# Altium schematic sheet ESSICC-ALM-SPI-232.SchDoc(ESSICC-ALM-SPI-232)
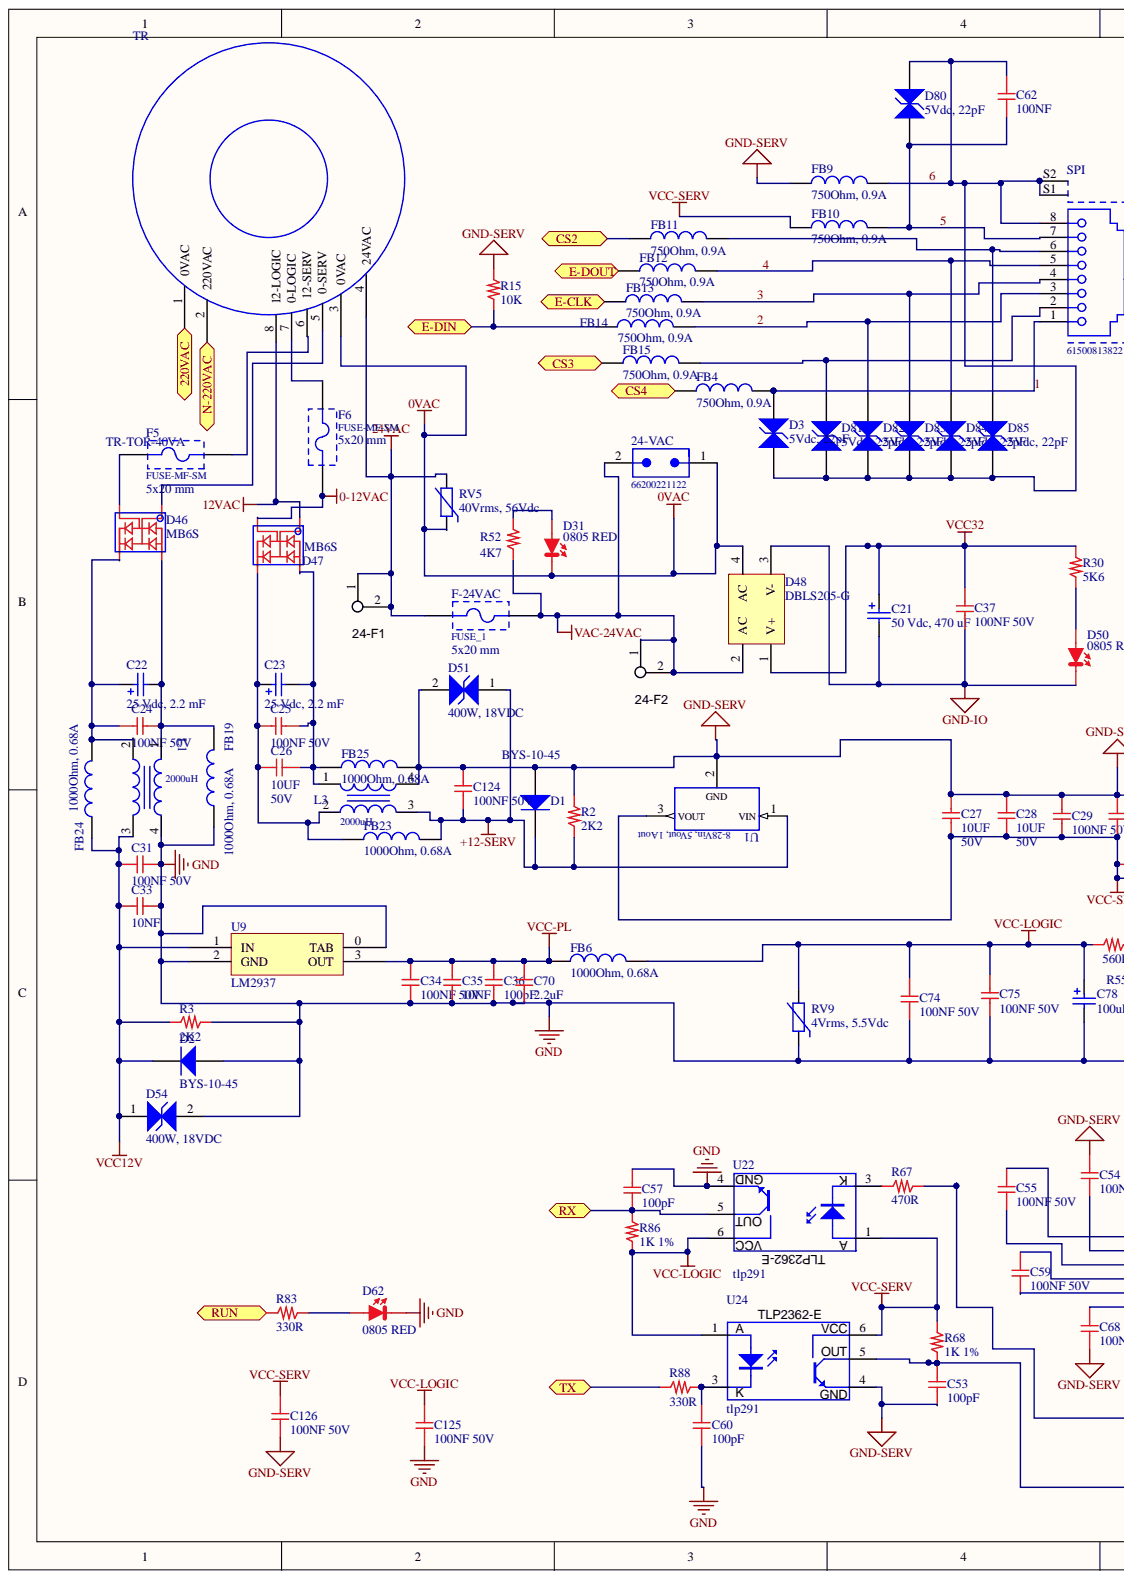
[diagram p0: ESSICC-ALM-SPI-232.SchDoc, left]
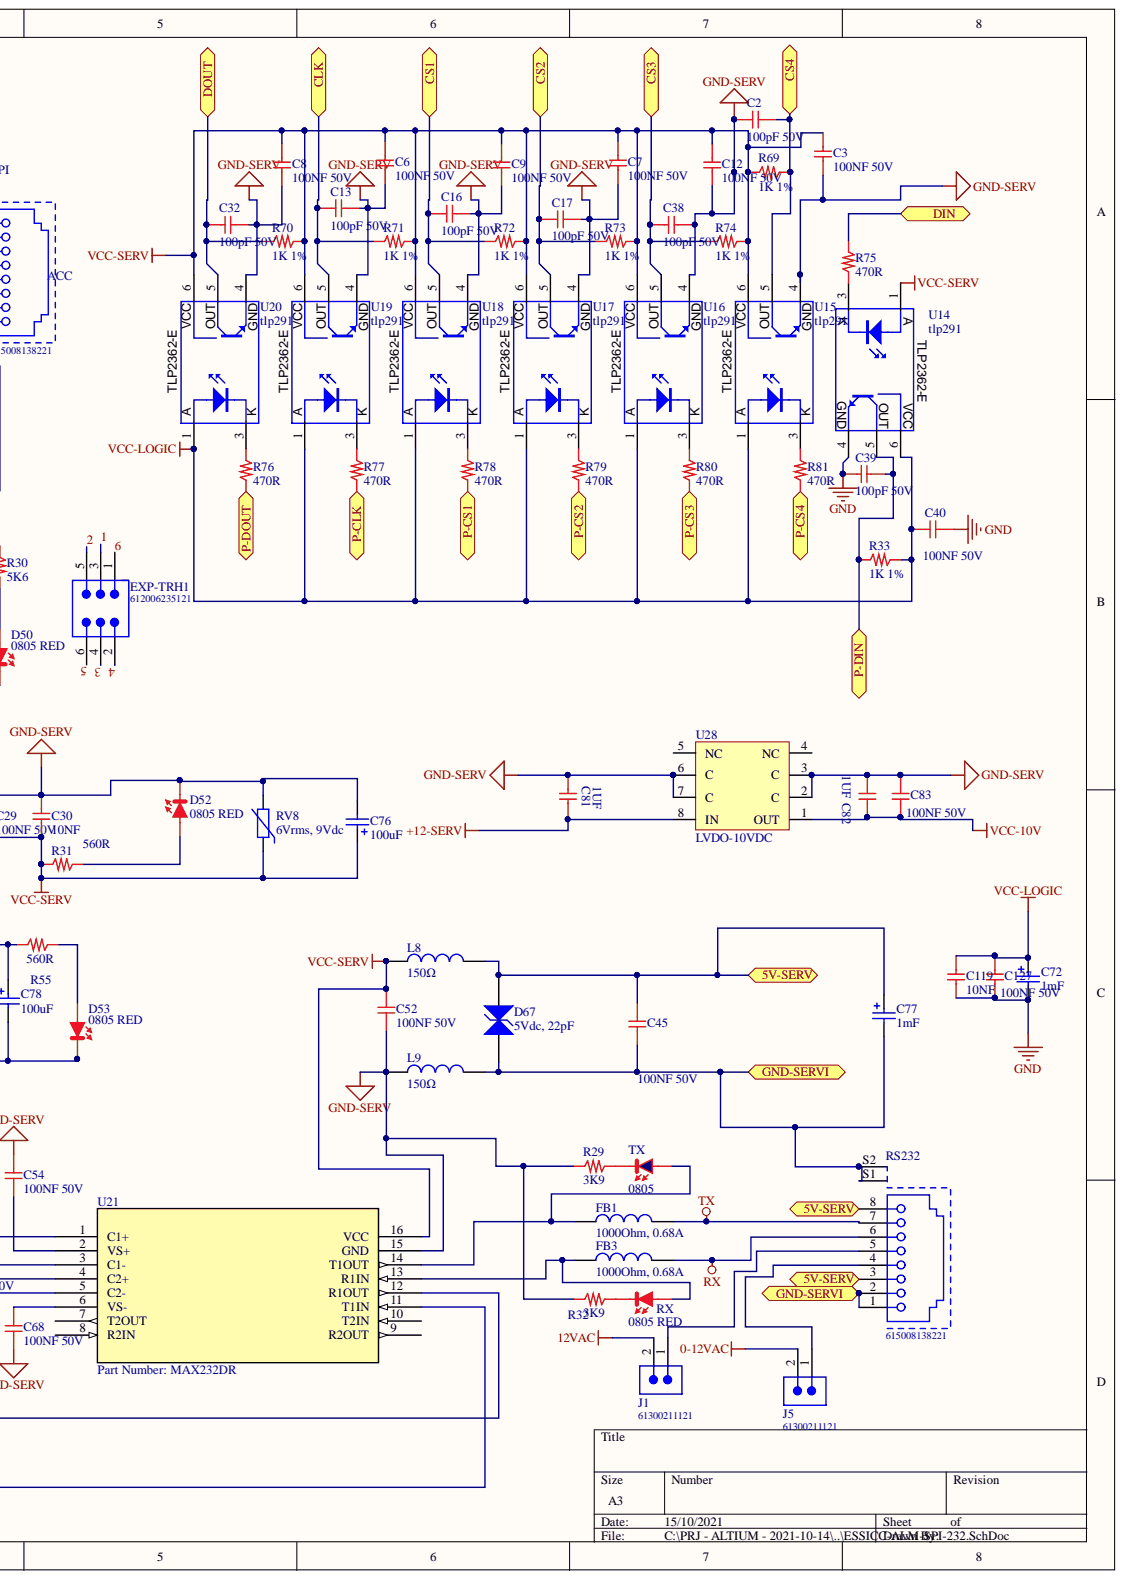
[diagram p1: ESSICC-ALM-SPI-232.SchDoc, right]

## Parts
24-F1  5012  [FASTOM-TERRA]  (value not drawn)
24-F2  5012  [FASTOM-TERRA]  (value not drawn)
24-VAC  66200221122  [66200221122]
C2  100pF 50V  [0402_C]
C3  100NF 50V  [0603_c]
C6  100NF 50V  [0603_c]
C7  100NF 50V  [0603_c]
C8  100NF 50V  [0603_c]
C9  100NF 50V  [0603_c]
C12  100NF 50V  [0603_c]
C13  100pF 50V  [0402_C]
C16  100pF 50V  [0402_C]
C17  100pF 50V  [0402_C]
C21  50 Vdc, 470 uF  value 470 uF  [WCAP-ATLI_13x20x5]
C22  25 Vdc, 2.2 mF  value 2.2 mF  [WCAP-ATLI_13x35x5]
C23  25 Vdc, 2.2 mF  value 2.2 mF  [WCAP-ATLI_13x35x5]
C24  100NF 50V  [0603_c]
C25  100NF 50V  [0603_c]
C26  10UF  Tensione: 50V  [1206_C]
C27  10UF  Tensione: 50V  [1206_C]
C28  10UF  Tensione: 50V  [1206_C]
C29  100NF 50V  [0603_c]
C30  10NF  [0603_c]
C31  100NF 50V  [0603_c]
C32  100pF 50V  [0402_C]
C33  10NF  [0603_c]
C34  100NF 50V  [0603_c]
C35  10NF  [0603_c]
C36  100pF  [0603_c]
C37  100NF 50V  [0603_c]
C38  100pF 50V  [0402_C]
C39  100pF 50V  [0402_C]
C40  100NF 50V  [0603_c]
C45  100NF 50V  [0603_c]
C52  100NF 50V  [0603_c]
C53  100pF  [0603_c]
C54  100NF 50V  [0603_c]
C55  100NF 50V  [0603_c]
C57  100pF  [0603_c]
C59  100NF 50V  [0603_c]
C60  100pF  [0603_c]
C62  100NF  [0603_c]
C68  100NF 50V  [0603_c]
C70  2.2uF  [0603_c]
C72  value 1mF  [WCAP-ASLL_8x10.5]  (hidden comment: 865060153010)
C74  100NF 50V  [0603_c]
C75  100NF 50V  [0603_c]
C76  value 100uF  [WCAP-ASLL_6.3x5.5]  (hidden comment: 865060143004)
C77  value 1mF  [WCAP-ASLL_8x10.5]  (hidden comment: 865060153010)
C78  value 100uF  [WCAP-ASLL_6.3x5.5]  (hidden comment: 865060143004)
C81  1UF  [0805_C]
C82  1UF  [0805_C]
C83  100NF 50V  [0603_c]
C119  10NF  [0603_c]
C124  100NF 50V  [0603_c]
C125  100NF 50V  [0603_c]
C126  100NF 50V  [0603_c]
C127  100NF 50V  [0603_c]
D1  BYS-10-45  [DO-214AC -B]
D2  BYS-10-45  [DO-214AC -B]
D3  5Vdc, 22pF  [WE-VE_0603]
D31  0805 RED  [0805_Red]
D46  MB6S  [MBS]
D47  MB6S  [MBS]
D48  DBLS205-G  [DBLS205-G]
D50  0805 RED  [0805_Red]
D51  400W, 18VDC  [WE-TVSP_DO-214AC_Bidirectional]
D52  0805 RED  [0805_Red]
D53  0805 RED  [0805_Red]
D54  400W, 18VDC  [WE-TVSP_DO-214AC_Bidirectional]
D62  0805 RED  [0805_Red]
D67  5Vdc, 22pF  [WE-VE_0603]
D80  5Vdc, 22pF  [WE-VE_0603]
D81  5Vdc, 22pF  Capacitance: 22pF  [WE-VE_0603]
D82  5Vdc, 22pF  Capacitance: 22pF  [WE-VE_0603]
D83  5Vdc, 22pF  Capacitance: 22pF  [WE-VE_0603]
D84  5Vdc, 22pF  Capacitance: 22pF  [WE-VE_0603]
D85  5Vdc, 22pF  Capacitance: 22pF  [WE-VE_0603]
EXP-TRH1  612006235121  [612006235121]
F5  FUSE-MF-SM  [FUSESMD]
F6  FUSE-MF-SM  Fuse Size: 5x20 mm  [FUSESMD]
F-24VAC  FUSE_1  [696108003002]
FB1  1000Ohm, 0.68A  Rated Current: 0.68A  [WE-CBF_0603_High Speed]
FB3  1000Ohm, 0.68A  Rated Current: 0.68A  [WE-CBF_0603_High Speed]
FB4  750Ohm, 0.9A  Rated Current: 0.9A  [WE-CBF_0603_Wide Band]
FB6  1000Ohm, 0.68A  Rated Current: 0.68A  [WE-CBF_0603_High Speed]
FB9  750Ohm, 0.9A  Rated Current: 0.9A  [WE-CBF_0603_Wide Band]
FB10  750Ohm, 0.9A  Rated Current: 0.9A  [WE-CBF_0603_Wide Band]
FB11  750Ohm, 0.9A  Rated Current: 0.9A  [WE-CBF_0603_Wide Band]
FB12  750Ohm, 0.9A  Rated Current: 0.9A  [WE-CBF_0603_Wide Band]
FB13  750Ohm, 0.9A  Rated Current: 0.9A  [WE-CBF_0603_Wide Band]
FB14  750Ohm, 0.9A  Rated Current: 0.9A  [WE-CBF_0603_Wide Band]
FB15  750Ohm, 0.9A  Rated Current: 0.9A  [WE-CBF_0603_Wide Band]
FB19  1000Ohm, 0.68A  Rated Current: 0.68A  [WE-CBF_0603_High Speed]
FB23  1000Ohm, 0.68A  Rated Current: 0.68A  [WE-CBF_0603_High Speed]
FB24  1000Ohm, 0.68A  Rated Current: 0.68A  [WE-CBF_0603_High Speed]
FB25  1000Ohm, 0.68A  Rated Current: 0.68A  [WE-CBF_0603_High Speed]
J1  61300211121  [61300211121]
J5  61300211121  [61300211121]
L1  2000uH  [WE-SL2]
L3  2000uH  [WE-SL2]
L8  150Ω  [WE-CBF_0805_High Speed]
L9  150Ω  [WE-CBF_0805_High Speed]
R2  2K2  [1206_R]
R3  2K2  [1206_R]
R15  10K  [0603_R]
R29  3K9  [0603_R]
R30  5K6  [1206_R]
R31  560R  [0603_R]
R32  3K9  [0603_R]  (value not drawn)
R33  1K 1%  [0603_R]
R52  4K7  [1206_R]
R55  560R  [0603_R]
R67  470R  [0603_R]
R68  1K 1%  [0603_R]
R69  1K 1%  [0603_R]
R70  1K 1%  [0603_R]
R71  1K 1%  [0603_R]
R72  1K 1%  [0603_R]
R73  1K 1%  [0603_R]
R74  1K 1%  [0603_R]
R75  470R  [0603_R]
R76  470R  [0603_R]
R77  470R  [0603_R]
R78  470R  [0603_R]
R79  470R  [0603_R]
R80  470R  [0603_R]
R81  470R  [0603_R]
R83  330R  [0603_R]
R86  1K 1%  [0603_R]
R88  330R  [0603_R]
RS232  615008138221  [615008138221]
RV5  40Vrms, 56Vdc  [WE-VD_7mm_820574001]
RV8  6Vrms, 9Vdc  [WE-VS_0805]
RV9  4Vrms, 5.5Vdc  [WE-VS_0805]
RX  0805 RED  [0805_Red]
SPI  615008138221  [615008138221]
TR  TR-TOR-40VA  [TR-TOR-40VA]
TX  0805  [0805-BLU]
U1  8-28Vin, 5Vout, 1Aout  [173010x78]
U9  LM2937  [SOT-223]
U14  tlp291  [TLP2362-E]
U15  tlp291  [TLP2362-E]
U16  tlp291  [TLP2362-E]
U17  tlp291  [TLP2362-E]
U18  tlp291  [TLP2362-E]
U19  tlp291  [TLP2362-E]
U20  tlp291  [TLP2362-E]
U21  Part Number: MAX232DR  [D16]
U22  tlp291  [TLP2362-E]
U24  tlp291  [TLP2362-E]
U28  LVDO-10VDC  [SO-8]

## Ports
5V-SERV, 220VAC, CLK, CS1, CS2, CS3, CS4, DIN, DOUT, E-CLK, E-DIN, E-DOUT, GND-SERVI, N-220VAC, P-CLK, P-CS1, P-CS2, P-CS3, P-CS4, P-DIN, P-DOUT, RUN, RX, TX

## Nets
0-12VAC: D47.2 F6.1 J5.2
0VAC: 24-VAC.1 D31.K D48.4(AC) RV5.1 TR.3(0VAC)
12VAC: D47.1 J1.2 TR.8(12-LOGIC)
24VAC: 24-F1.1 24-F1.2 F-24VAC.1 RV5.2 TR.4(24VAC)
+12-SERV: C81.2 C124.1 D1.1 D51.1 FB23.2 L3.3 R2.1 U1.1 U28.8(IN)
GND: C31.2 C33.2 C34.1 C35.1 C36.2 C39.1 C40.1 C57.1 C60.2 C70.2 C72.2 C74.1 C75.1 C78.2 C119.1 C125.2 C127.2 D2.2 D53.K D54.2 D62.K FB19.1 L1.4 R3.1 RV9.1 U9.0(TAB) U9.2(GND) U14.4 U22.4
GND-IO: C21.2 C37.1 D48.3(V-) D50.K
GND-SERV: C2.1 C3.1 C6.1 C7.1 C8.1 C9.1 C12.1 C13.2 C16.2 C17.2 C27.2 C28.2 C29.2 C30.2 C32.2 C38.2 C52.2 C53.2 C54.1 C68.2 C76.2 C81.1 C82.1 C83.1 C124.2 C126.2 D1.2 D51.2 D52.K FB9.1 FB25.2 L3.4 L9.1 R2.2 R15.1 R29.1 R32.1 RV8.2 U1.2 U15.4 U16.4 U17.4 U18.4 U19.4 U20.4 U21.15(GND) U24.4 U28.2(C) U28.3(C) U28.6(C) U28.7(C)
RX: FB3.2 RS232.6
TX: FB1.2 RS232.7
VAC-24VAC: 24-F2.1 24-F2.2 24-VAC.2 D48.2(AC) F-24VAC.2 R52.1
VCC12V: C31.1 C33.1 D2.1 D54.1 FB24.1 L1.3 R3.2 U9.1(IN)
VCC32: C21.1 C37.2 D48.1(V+) R30.2
VCC-10V: C82.2 C83.2 U28.1(OUT)
VCC-LOGIC: C40.2 C72.1 C74.2 C75.2 C78.1 C119.2 C125.1 C127.1 FB6.2 R33.1 R55.1 R86.2 RV9.2 U14.6 U15.1(A) U16.1 U17.1(A) U18.1(A) U19.1(A) U20.1(A) U22.6 U24.1
VCC-PL: C34.2 C35.2 C36.1 C70.1 FB6.1 U9.3(OUT)
VCC-SERV: C3.2 C6.2 C7.2 C8.2 C9.2 C12.2 C27.1 C28.1 C29.1 C30.1 C52.1 C76.1 C126.1 FB10.1 L8.1 R31.1 R68.1 R69.1 R70.1 R71.1 R72.1 R73.1 R74.1 RV8.1 U1.3 U14.1 U15.6(VCC) U16.6 U17.6(VCC) U18.6(VCC) U19.6(VCC) U20.6(VCC) U21.16(VCC) U22.1 U24.6
1: D3.2 EXP-TRH1.3 FB4.2 SPI.1
2: D82.2 EXP-TRH1.5 FB14.2 SPI.3
3: D83.2 EXP-TRH1.4 FB13.2 SPI.4
4: D84.2 EXP-TRH1.2 FB12.2 SPI.5
5: C62.1 D80.1 EXP-TRH1.6 FB10.2 SPI.7
5V-SERV: C45.2 C77.1 D67.2 L8.2 RS232.3 RS232.8 ; port 5V-SERV
6: C62.2 D3.1 D80.2 D81.1 D82.1 D83.1 D84.1 D85.1 EXP-TRH1.1 FB9.2 SPI.8 SPI.S1 SPI.S2
220VAC: TR.1(0VAC) ; port 220VAC
CLK: C13.1 R71.2 U19.5(OUT) ; port CLK
CS1: C16.1 R72.2 U18.5(OUT) ; port CS1
CS2: C17.1 FB11.1 R73.2 U17.5(OUT) ; port CS2
CS3: C38.1 FB15.1 R74.2 U16.5 ; port CS3
CS4: C2.2 FB4.1 R69.2 U15.5(OUT) ; port CS4
DIN: R75.2 ; port DIN
DOUT: C32.1 R70.2 U20.5(OUT) ; port DOUT
E-CLK: FB13.1 ; port E-CLK
E-DIN: FB14.1 R15.2 ; port E-DIN
E-DOUT: FB12.1 ; port E-DOUT
GND-SERVI: C45.1 C77.2 D67.1 L9.2 RS232.1 RS232.2 RS232.S1 RS232.S2 ; port GND-SERVI
N-220VAC: TR.2(220VAC) ; port N-220VAC
P-CLK: R77.1 ; port P-CLK
P-CS1: R78.1 ; port P-CS1
P-CS2: R79.1 ; port P-CS2
P-CS3: R80.1 ; port P-CS3
P-CS4: R81.1 ; port P-CS4
P-DIN: C39.2 R33.2 U14.5 ; port P-DIN
P-DOUT: R76.1 ; port P-DOUT
RUN: R83.1 ; port RUN
NetC22_1: C22.1 C24.1 D46.3 FB24.2 L1.2
NetC22_2: C22.2 C24.2 D46.4 FB19.2 L1.1
NetC23_1: C23.1 C25.1 C26.1 D47.3 FB23.1 L3.2
NetC23_2: C23.2 C25.2 C26.2 D47.4 FB25.1 L3.1(1000Ohm,)
NetC53_1: C53.1 R68.2 U21.11(T1IN) U24.5
NetC54_2: C54.2 U21.2(VS+)
NetC55_1: C55.1 U21.1(C1+)
NetC55_2: C55.2 U21.3(C1-)
NetC57_2: C57.2 R86.1 U22.5 ; port RX
NetC59_1: C59.1 U21.4(C2+)
NetC59_2: C59.2 U21.5(C2-)
NetC60_1: C60.1 R88.2 U24.3
NetC68_1: C68.1 U21.6(VS-)
NetD31_A: D31.A R52.2
NetD46_1: D46.1 TR.5(0-SERV)
NetD46_2: D46.2 F5.2
NetD50_A: D50.A R30.1
NetD52_A: D52.A R31.2
NetD53_A: D53.A R55.2
NetD62_A: D62.A R83.2
NetD81_2: D81.2 FB15.2 SPI.2
NetD85_2: D85.2 FB11.2 SPI.6
NetF5_1: F5.1 TR.6(12-SERV)
NetF6_2: F6.2 TR.7(0-LOGIC)
NetFB1_1: FB1.1 TX.A U21.14(T1OUT)
NetFB3_1: FB3.1 RX.A U21.13(R1IN)
NetJ1_1: J1.1 RS232.5
NetJ5_1: J5.1 RS232.4
NetR29_2: R29.2 TX.K
NetR32_2: R32.2 RX.K
NetR67_1: R67.1 U22.3
NetR67_2: R67.2 U21.12(R1OUT)
NetR75_1: R75.1 U14.3
NetR76_2: R76.2 U20.3
NetR77_2: R77.2 U19.3
NetR78_2: R78.2 U18.3
NetR79_2: R79.2 U17.3
NetR80_2: R80.2 U16.3
NetR81_2: R81.2 U15.3
NetR88_1: R88.1 ; port TX

Not connected: U21 7(T2OUT) 8(R2IN) 9(R2OUT) 10(T2IN); U28 4(NC) 5(NC)

## Library data of the parts
24-F1 24-F2 = TERRA: Test Point, Multipurpose, White, TH; Manufacturer: Keystone; ContactFinish: Silver; CompType: Test Point Loop; SymbolVersion: 2008-04-04; Supplier Part Number 2: 534-5012; Supplier Part Number 1: 36-5012-ND; Supplier 2: Mouser; Supplier 1: Digi-Key; RoHS: Y; PartNumber: 5012; PackageReference: White Multipurpose Testpoint; MountType: TH; LatestRevisionDate: 2017-01-19; ComponentLink1URL: http://www.keyelco.com/userAssets/file/M60-2p60.pdf; ComponentLink1Description: Datasheet URL; Component Kind: Standard; Color: White; Publisher: Texas Instruments; Library Name: HSW.SchLib
24-VAC = 66200221122: THT Male Header WR-MPC3, Vertical, Dual Row, pitch 3 mm, 2 pins; Working Voltage: 250 VAC; Pitch: 3 mm; Pin Number: 2; Contact Resistance: 10 mOhm; Published Date: September 2018; Part Number: 66200221122; Packaging: Tube; Orientation: Vertical; Operating Temperature: -40 to 105 degC; Mounting Technology: THT; Library Name: WR-MPC3.SchLib; Gender: Male; ComponentLink2URL: https://katalog.we-online.de/em/datasheet/6620xx21122.pdf; ComponentLink2Description: Datasheet; ComponentLink1URL: http://www.we-online.de; ComponentLink1Description: Manufacturer; Component Kind: Standard; Publisher: Wurth Elektronik
C2 C13 C16 C17 C32 C38 C39 = 100pF 50V: Cap COG 100pF 50V; Produttore: ??; Cod. Interno: COCS506565000101; Library Name: CapSMD0402.SchLib; Component Kind: Standard; Cod. Produttore: ??
C3 C6-C9 C12 C24 C25 C29 C31 C34 C37 C40 C45 C52 C54 C55 C59 C68 C74 C75 C83 C124-C127 = 100NF 50V: Cap X7R 100nF 50V Flex Terminal; Produttore: Samsung; Cod. Produttore: CL10B104KB8SFNC; Cod. Interno: COCS50665050104; Library Name: CapSMD0603.SchLib; Component Kind: Standard; Cod. DigiKey: 1276-1936-2-ND
C21 = 860080678019: WCAP-ATLI Aluminum Electrolytic Capacitors, 13x20mm, 50 Vdc, 470 uF; Value: 470 uF; Rated Voltage: 50 Vdc; Size: 13x20mm; Published Date: Sep. 2017; Part Number: 860080678019; Operating Temperature: -55 °C up to +105 °C; Life Cycle: 5000h; Library Name: WCAP-ATLI.SchLib; ComponentLink2URL: http://katalog.we-online.com/pbs/datasheet/860080678019.pdf; ComponentLink2Description: Datasheet; ComponentLink1URL: http://www.we-online.de; ComponentLink1Description: Manufacturer; Component Kind: Standard; Publisher: Wurth Elektronik
C22 = 860080478024: WCAP-ATLI Aluminum Electrolytic Capacitors, 13x35mm, 25 Vdc, 2.2 mF; Value: 2.2 mF; Rated Voltage: 25 Vdc; Size: 13x35mm; Published Date: Sep. 2017; Part Number: 860080478024; Operating Temperature: -55 °C up to +105 °C; Life Cycle: 5000h; Library Name: WCAP-ATLI.SchLib; ComponentLink2URL: http://katalog.we-online.com/pbs/datasheet/860080478024.pdf; ComponentLink2Description: Datasheet; ComponentLink1URL: http://www.we-online.de; ComponentLink1Description: Manufacturer; Component Kind: Standard; Publisher: Wurth Elektronik
C23 = 860080478024: WCAP-ATLI Aluminum Electrolytic Capacitors, 13x35mm, 25 Vdc, 2.2 mF; Rated Voltage: 25 Vdc; Size: 13x35mm; Published Date: Sep. 2017; Part Number: 860080478024; Operating Temperature: -55 °C up to +105 °C; Life Cycle: 5000h; Library Name: WCAP-ATLI.SchLib; ComponentLink2URL: http://katalog.we-online.com/pbs/datasheet/860080478024.pdf; ComponentLink2Description: Datasheet; ComponentLink1URL: http://www.we-online.de; ComponentLink1Description: Manufacturer; Component Kind: Standard; Publisher: Wurth Elektronik
C26-C28 = 10UF 50V: Cap X5R 10UF 50V; Produttore: MURATA; Cod. Produttore: GRM31CR61H106KA12L; Temperature Range: Da -55°C a +125°C; Library Name: CapSMD1206.SchLib; Component Kind: Standard; Cod. Farnell: 2666583
C30 C33 C35 C62 C119 = 10NF 50V: Cap X7R 10nF 50V; Produttore: Samsung; Cod. Produttore: CL05B103KB5NNNC; Cod. Interno: COCS 556655000103; Library Name: CapSMD0603.SchLib; Component Kind: Standard; Cod. DigiKey: 1276-1028-2-ND
C36 C53 C57 C60 = 100pF 50V: Cap X7R 100pF 50V; Produttore: ??; Cod. Interno: COCS??; Library Name: CapSMD0603.SchLib; Component Kind: Standard; Cod. Produttore: ??
C70 = 2.2uF 10V: 2.2 µF, 10 V, ± 10%, X5R 0603; Produttore: WALSIN; Costo 1000: 0,0183; Costo 100: 0,0436; Cod. Produttore: 0603X225K100CT; Cod. Interno: COCS??; Library Name: CapSMD0603.SchLib; ComponentLink1URL: http://it.farnell.com/walsin/0603x225k100ct/cond-mlcc-x5r-2-2uf-10v-0603-bob/dp/2495422?st=2.2uf; ComponentLink1Description: FARNELL; Component Kind: Standard
C72 C77 = 865060153010: WCAP-ASLL Aluminum Electrolytic Capacitors, size Ф8x10.5mm, 6.3V DC, 1mF; Rated Voltage: 6.3V DC; Size: Ф8x10.5mm; Published Date: June 2017; Part Number: 865060153010; Operating Temperature: -55 °C up to +105 °C; Life Cycle: 5000h; Library Name: WCAP-ASLL.SchLib; ComponentLink2URL: http://katalog.we-online.de/pbs/datasheet/865060153010.pdf; ComponentLink2Description: Datasheet; ComponentLink1URL: http://www.we-online.de; ComponentLink1Description: Manufacturer; Component Kind: Standard; Publisher: Wurth Elektronik
C76 C78 = 865060143004: WCAP-ASLL Aluminum Electrolytic Capacitors, size Ф6.3x5.5mm, 6.3V DC, 100uF; Rated Voltage: 6.3V DC; Size: Ф6.3x5.5mm; Published Date: June 2017; Part Number: 865060143004; Operating Temperature: -55 °C up to +105 °C; Life Cycle: 2000h; Library Name: WCAP-ASLL.SchLib; ComponentLink2URL: http://katalog.we-online.de/pbs/datasheet/865060143004.pdf; ComponentLink2Description: Datasheet; ComponentLink1URL: http://www.we-online.de; ComponentLink1Description: Manufacturer; Component Kind: Standard; Publisher: Wurth Elektronik
C81 C82 = 1UF 100V: Cap X7S 1UF 100V; Produttore: TDK; Cod. Produttore: C2012X7S2A105K125AE; Cod. Interno: COCS 567061010105; Temperature Range: Da -55°C a +125°C; Library Name: CapSMD0805.SchLib; Component Kind: Standard; Cod. DigiKey: 445-8887-2-ND
D1 D2 = BYS-10-45: High Conductance Fast Diode; Published: 8-Jun-2000; PackageReference: DO-35; PackageDescription: Diode, Axial; Body 3.8 x 1.9 mm (LxDia. max), Lead Dia. 0.53 mm (max); LatestRevisionNote: Re-released for DXP Platform.; LatestRevisionDate: 17-Jul-2002; DatasheetVersion: 1997; ComponentLink2URL: http://www.fairchildsemi.com/ds/1N%2F1N4148.pdf; ComponentLink2Description: Datasheet; ComponentLink1URL: http://www.fairchildsemi.com/; ComponentLink1Description: Manufacturer Link; Component Kind: Standard; Code_JEDEC: DO-204-AH; Publisher: Altium Limited; Library Name: HSW.SchLib
D3 D67 D80-D85 = 82356050220: WE-VE ESD Suppressor, size 0603, 5Vdc, 22pF; Leakage Current: 1uA; ESD Voltage air discharge: 15kV; Clamp Voltage: 55V; Working Voltage: 5Vdc; Size: 0603; Published Date: June 2019; Part Number: 82356050220; Packaging: Tape and Reel; Operating Temperature: -40 to +85degC; Mounting Technology: SMT; Library Name: WE-VE_0603.SchLib; ComponentLink2URL: https://katalog.we-online.com/pbs/datasheet/82356050220.pdf; ComponentLink2Description: Datasheet; ComponentLink1URL: http://www.we-online.de; ComponentLink1Description: Manufacturer; Component Kind: Standard; Publisher: Wurth Elektronik
  D3 D67 D80: Capacitance: 22pF
D31 D50 D52 D53 D62 RX = LED_ROSSO_0805: LED Rosso 0805; V: 2.0V; Produttore: WE; Luminosità: 150mcd; Cod. Produttore: 150080RS75000; Cod. Interno: LEDS 2AC080RS7500; Component Kind: Standard; Library Name: DiodiLED.SchLib
D46 D47 = MB6S: Surface Mount Bridge Rectifier; Vr: 600V; Produttore: VISHAY; If(AV): 0.5A; Cod. Interno: DIO ??; Component Kind: Standard; Cod. Produttore: MB6S; Library Name: Diodi.SchLib
D48 = DBLS205-G: Diode Bridge; Simulation: BRIDGE; Published: 24-Mar-1999; PackageReference: D-46_6A; PackageDescription: Bridge Rectifier; 4 Terminals; Body 16.8 x 16.8 mm (typ); Pitch 10.67 mm; LatestRevisionNote: Re-released for DXP Platform.; LatestRevisionDate: 17-Jul-2002; Component Kind: Standard; Publisher: Altium Limited; Library Name: HSW.SchLib
D51 D54 = 824501181: WE-TVSP Power TVS Diode, DO-214AC, 400W, 18VDC, Bidirectional; DC Operating Voltage: 18V; Clamping Voltage: 29.2V; (Reverse) Breakdown Voltage: 21.05V; (Forward) Peak Pulse Current: 13.7A; Steady State Power Dissipation: 400W; Size: DO-214AC; Published Date: March 2019; Polarity: Bidirectional; Part Number: 824501181; Packaging: Tape and Reel; Operating Temperature: -65 to +150degC; Mounting Technology: SMT; Library Name: WE-TVSP_DO-214AC_Bidirectional.SchLib; ComponentLink2URL: https://katalog.we-online.com/pbs/datasheet/824501181.pdf; ComponentLink2Description: Datasheet; ComponentLink1URL: http://www.we-online.de; ComponentLink1Description: Manufacturer; Component Kind: Standard; Publisher: Wurth Elektronik
EXP-TRH1 = 612006235121: Male Box Header WR-BHD, THT, Vertical, pitch 2.54 mm, 6 pins; Working Voltage: 250 VAC; Withstanding Voltage: 500 VAC; Pitch: 2.54 mm; Pin Number: 6; Contact Resistance: 20 mOhm; Published Date: August 2018; Part Number: 612006235121; Packaging: Tray; Orientation: Vertical; Operating Temperature: -40 to 125 degC; Mounting Technology: THT; Library Name: WR-BHD_Box Headers_2.54mm.SchLib; Gender: Male; ComponentLink2URL: https://katalog.we-online.de/em/datasheet/6120xx235121.pdf; ComponentLink2Description: Datasheet; ComponentLink1URL: http://www.we-online.de; ComponentLink1Description: Manufacturer; Component Kind: Standard; Publisher: Wurth Elektronik
F5 = FUSE-MF-SM: MF-SM100-33-2 Fusibile ripristinabile PPTC, 2920 (7351 Metrico), Serie MF-SM, 33 VDC, 1.1 A, 2.2 A, 0.5 s; Part Number: 696108003002; Fuse Size: 5x20 mm; Published Date: August 2018; Packaging: Box; Operating Temperature: -30 to 120 degC; Mounting Technology: THT; ComponentLink2URL: https://katalog.we-online.de/em/datasheet/696108003002.pdf; ComponentLink2Description: Datasheet; ComponentLink1URL: http://www.we-online.de; ComponentLink1Description: Manufacturer; Component Kind: Standard; Publisher: Wurth Elektronik; Library Name: HSW.SchLib
F6 = FUSE-MF-SM: MF-SM100-33-2 Fusibile ripristinabile PPTC, 2920 (7351 Metrico), Serie MF-SM, 33 VDC, 1.1 A, 2.2 A, 0.5 s; Part Number: 696108003002; Published Date: August 2018; Packaging: Box; Operating Temperature: -30 to 120 degC; Mounting Technology: THT; ComponentLink2URL: https://katalog.we-online.de/em/datasheet/696108003002.pdf; ComponentLink2Description: Datasheet; ComponentLink1URL: http://www.we-online.de; ComponentLink1Description: Manufacturer; Component Kind: Standard; Publisher: Wurth Elektronik; Library Name: HSW.SchLib
F-24VAC = FUSE_1: WR-FSH Fuse Holder Blocks Fuse Holder Blocks Clip Cover for PCB; Fuse Size: 5x20 mm; Published Date: August 2018; Part Number: 696108003002; Packaging: Box; Operating Temperature: -30 to 120 degC; Mounting Technology: THT; ComponentLink2URL: https://katalog.we-online.de/em/datasheet/696108003002.pdf; ComponentLink2Description: Datasheet; ComponentLink1URL: http://www.we-online.de; ComponentLink1Description: Manufacturer; Component Kind: Standard; Publisher: Wurth Elektronik; Library Name: HSW.SchLib
FB1 FB3 FB6 FB19 FB23-FB25 = 742792664: WE-CBF SMT EMI Suppression Ferrite Bead, size 0603, 1000Ohm, 0.68A; Type: High Speed; Impedance max.: 1100Ohm; DC Resistance max.: 0.6Ohm; Size: 0603; Published Date: March 2019; Part Number: 742792664; Packaging: Tape and Reel; Operating Temperature: -55 to +125degC; Mounting Technology: SMT; Library Name: WE-CBF_0603.SchLib; Impedance@100 MHz: 1000Ohm; ComponentLink2URL: https://katalog.we-online.com/pbs/datasheet/742792664.pdf; ComponentLink2Description: Datasheet; ComponentLink1URL: http://www.we-online.de; ComponentLink1Description: Manufacturer; Component Kind: Standard; Publisher: Wurth Elektronik
FB4 FB9-FB15 = 742792656: WE-CBF SMT EMI Suppression Ferrite Bead, size 0603, 750Ohm, 0.9A; Type: Wide Band; Impedance max.: 800Ohm; DC Resistance max.: 0.35Ohm; Size: 0603; Published Date: March 2019; Part Number: 742792656; Packaging: Tape and Reel; Operating Temperature: -55 to +125degC; Mounting Technology: SMT; Library Name: WE-CBF_0603.SchLib; Impedance@100 MHz: 750Ohm; ComponentLink2URL: https://katalog.we-online.com/pbs/datasheet/742792656.pdf; ComponentLink2Description: Datasheet; ComponentLink1URL: http://www.we-online.de; ComponentLink1Description: Manufacturer; Component Kind: Standard; Publisher: Wurth Elektronik
J1 J5 = 61300211121: THT Vertical Pin Header WR-PHD, Pitch 2.54 mm, Single Row, 2 pins; Working Voltage: 250 VAC; Withstanding Voltage: 500 VAC; Rated Current: 3 A; Pitch: 2.54 mm; Pin Number: 2; Gender: Male; Contact Resistance: 20 mOhm; Row Number: Single; Published Date: August 2018; Part Number: 61300211121; Packaging: Bag; Orientation: Vertical; Operating Temperature: -40 to 105 degC; Mounting Technology: THT; Library Name: Pin Header WR-PHD_2.54 mm.SchLib; ComponentLink2URL: https://katalog.we-online.de/em/datasheet/6130xx11121.pdf; ComponentLink2Description: Datasheet; ComponentLink1URL: http://www.we-online.de; ComponentLink1Description: Manufacturer; Component Kind: Standard; Publisher: Wurth Elektronik
L1 L3 = 744221: WE-SL2 SMT Common Mode Line Filter, 2000uH, 600mA, 80V; Winding Style: bifilar; Leakage Inductance: 130nH; Insulation Test Voltage: 500V (AC); Impedance max.: 9200Ohm; DC Resistance max.: 0.42Ohm; Rated Voltage: 80V; Rated Current: 600mA; Published Date: March 2019; Part Number: 744221; Packaging: Tape and Reel; Operating Temperature: -40 to +125degC; Mounting Technology: SMT; Library Name: WE-SL2.SchLib; Inductance: 2000uH; ComponentLink2URL: https://katalog.we-online.com/pbs/datasheet/744221.pdf; ComponentLink2Description: Datasheet; ComponentLink1URL: http://www.we-online.de; ComponentLink1Description: Manufacturer; Component Kind: Standard; Publisher: Wurth Elektronik
L8 L9 = WE-CBF, 74279203: WE-CBF SMD EMI Suppression Ferrite Bead, 0805, 150Ω; Type: High Speed; Value: 150Ω; Size: 0805; PublishedDate: Aug 2016; PartNumber: 74279203; Library Name: WE-CBF_0805.SchLib; ComponentLink2URL: http://katalog.we-online.de/pbs/datasheet/74279203.pdf; ComponentLink2Description: Datasheet; ComponentLink1URL: http://www.we-online.de; ComponentLink1Description: Manufacturer; Component Kind: Standard; Publisher: Wurth Elektronik
R2 R3 R30 = 2K2: 2K2 1206 1/4W; Library Name: ResSMD1206.SchLib; Component Kind: Standard; Case: 1206
R15 = 10K: Res Film Spesso 1/16W 10K 5%; Produttore: ??; Cod. Interno: RESS4166556B0103??; Library Name: ResSMD0603.SchLib; Component Kind: Standard; Cod. Produttore: ??
R29 R32 = 3K9: Res Film Spesso 1/16W 3K9 5%; Produttore: VISHAY; Cod. Produttore: CRCW06033K90JNEA; Cod. Interno: RESS4166556B0392; Library Name: ResSMD0603.SchLib; Component Kind: Standard
R31 R55 = 560R: Res Film Spesso 1/16W 560R 5%; Cod. Interno: RESS 4166556B0561; Library Name: ResSMD0603.SchLib; Component Kind: Standard
R33 R68-R74 R86 = 1K 1%: Res Film Spesso 1/16W 1K 1%; Produttore: ??; Cod. Interno: RESS4166356B0102; Library Name: ResSMD0603.SchLib; Component Kind: Standard; Cod. Produttore: ??
R52 = 10K: 4K7 1206 1/4W; Library Name: ResSMD1206.SchLib; Component Kind: Standard; Case: 1206
R67 R75 = 470R: Res Film Spesso 1/16W 470R 5%; Produttore: ??; Cod. Interno: RESS4166556B0471??; Library Name: ResSMD0603.SchLib; Component Kind: Standard; Cod. Produttore: ??
R76-R81 R83 R88 = 330R: Res Film Spesso 1/16W 330R 5%; Cod. Interno: RESS4166556B0331; Library Name: ResSMD0603.SchLib; Component Kind: Standard
RS232 SPI = 615008138221: Modular Jack WR-MJ, Front Post, Shielded, Vertical Entry, THT, 8P8C; Working Voltage: 120 VAC; Withstanding Voltage: 1000 VAC; Rated Current: 1.5 A; Port: 1x1; Contact Resistance: 20 mOhm; Compliance: Lead free / RoHS; Published Date: July 2018; Pin Number: 8P8C; Part Number: 615008138221; Packaging: Tray; Operating Temperature: -40 to 85 degC; Mounting Technology: THT; Library Name: RJ45-8.SchLib; Entrance: Vertical; ComponentLink2URL: https://katalog.we-online.com/em/datasheet/615008138221.pdf; ComponentLink2Description: Datasheet; ComponentLink1URL: http://www.we-online.de; ComponentLink1Description: Manufacturer; Component Kind: Standard; Publisher: Wurth Elektronik
RV5 = 820574001: WE-VD Disk Varistor, size 7mm, 40Vrms, 56Vdc; Power Dissipation: 0.02W; Energy Absorbtion: 4.3J; Clamping Voltage: 135V; (Reverse) Peak Pulse Current: 250A; (Reverse) Breakdown Voltage: 68V; (Channel) Input Capacitance: 1120pF; Size: 7mm; Published Date: March 2019; Part Number: 820574001; Packaging: Tape and Reel; Operating Temperature: -40 to +85degC; Mounting Technology: THT; DC Operating Voltage: 56Vdc; ComponentLink2URL: https://katalog.we-online.com/pbs/datasheet/820574001.pdf; ComponentLink2Description: Datasheet; ComponentLink1URL: http://www.we-online.de; ComponentLink1Description: Manufacturer; Component Kind: Standard; AC Operating Voltage: 40Vrms; Publisher: Wurth Elektronik; Library Name: MOV_7mm.SchLib
RV8 = 82550060: WE-VS SMD Varistor, size 0805, 6Vrms, 9Vdc; Withstanding Surge Current: 80A; Varistor Voltage: 12V; Energy Dissipation: 0.1J; Clamping Voltage: 20V; Size: 0805; Published Date: March 2019; Part Number: 82550060; Packaging: Tape and Reel; Operating Temperature: -40 to +85degC; Mounting Technology: SMT; Library Name: WE-VS_0805.SchLib; DC Operating Voltage: 9Vdc; ComponentLink2URL: https://katalog.we-online.com/pbs/datasheet/82550060.pdf; ComponentLink2Description: Datasheet; ComponentLink1URL: http://www.we-online.de; ComponentLink1Description: Manufacturer; Component Kind: Standard; AC Operating Voltage: 6Vrms; Publisher: Wurth Elektronik
RV9 = 82550040: WE-VS SMD Varistor, size 0805, 4Vrms, 5.5Vdc; Withstanding Surge Current: 80A; Varistor Voltage: 8V; Energy Dissipation: 0.1J; Clamping Voltage: 18V; Size: 0805; Published Date: March 2019; Part Number: 82550040; Packaging: Tape and Reel; Operating Temperature: -40 to +85degC; Mounting Technology: SMT; Library Name: WE-VS_0805.SchLib; DC Operating Voltage: 5.5Vdc; ComponentLink2URL: https://katalog.we-online.com/pbs/datasheet/82550040.pdf; ComponentLink2Description: Datasheet; ComponentLink1URL: http://www.we-online.de; ComponentLink1Description: Manufacturer; Component Kind: Standard; AC Operating Voltage: 4Vrms; Publisher: Wurth Elektronik
TX = LED BLU 0805: Vf(typ): 3.3V; Produttore: kingbright; Luminosità: 100mcd 20mA; Cod. Produttore: KPTR-3216QBC-D; Description: LED BLU 0805; Component Kind: Standard; Library Name: DiodiLED.SchLib
U1 = 173010578: MagI3C-FDSM Fixed Step Down Regulator Module, SIP-3, 8-28Vin, 5Vout, 1Aout; Output Voltage: 5V; Output Current: 1A; Input Voltage: 8-28V; Width: 8.5mm; Size: SIP-3; Published Date: March 2020; Packaging: Tube; Operating Temperature: -40 to +85degC; Mounting Technology: THT; Manufacturer Part Number: 173010578; Library Name: MagI3C-FDSM.SchLib; Length: 11.6mm; Height: 10.5mm; ComponentLink2URL: https://www.we-online.com/catalog/datasheet/173010578.pdf; ComponentLink2Description: Datasheet; ComponentLink1URL: http://www.we-online.de; ComponentLink1Description: Manufacturer; Component Kind: Standard; Publisher: Wurth Elektronik
U14-U20 U22 U24 = TLP2362-E: 4-Pin Phototransistor Optocoupler; Published: 24-Mar-1999; PackageReference: DIP-4; LatestRevisionNote: Sch pins 3 & 4 Swapped.; LatestRevisionDate: 04-Mar-2005; DatasheetVersion: Nov-2003; Component Kind: Standard; Publisher: Altium Limited; Library Name: HSW.SchLib
U21 = MAX232DR: No Description Available; Vendor: Texas Instruments; Built_By: EMA_UL_Team; Value: Value; Type: DEV; RefDes: RefDes; Manufacturer_Part_Number: MAX232DR; Manufacturer: Texas Instruments; Library Name: MAX232DR.SchLib; Component Kind: Standard; Copyright: Copyright (C) 2018 Accelerated Designs. All rights reserved
U28 = TL050L10CD-R: TEXAS-LOW-DROPOUT VOLTAGEREGULATORS-26VIN-10VOUT; Component Kind: Standard; Library Name: HSW.SchLib
TR = TR-TOR-40VA: Component Kind: Standard; Library Name: HSW.SchLib
U9 = LM2937: Component Kind: Standard; Library Name: HSW.SchLib
